# Altium schematic sheet FPGA_MISC.SchDoc(FPGA_MISC)
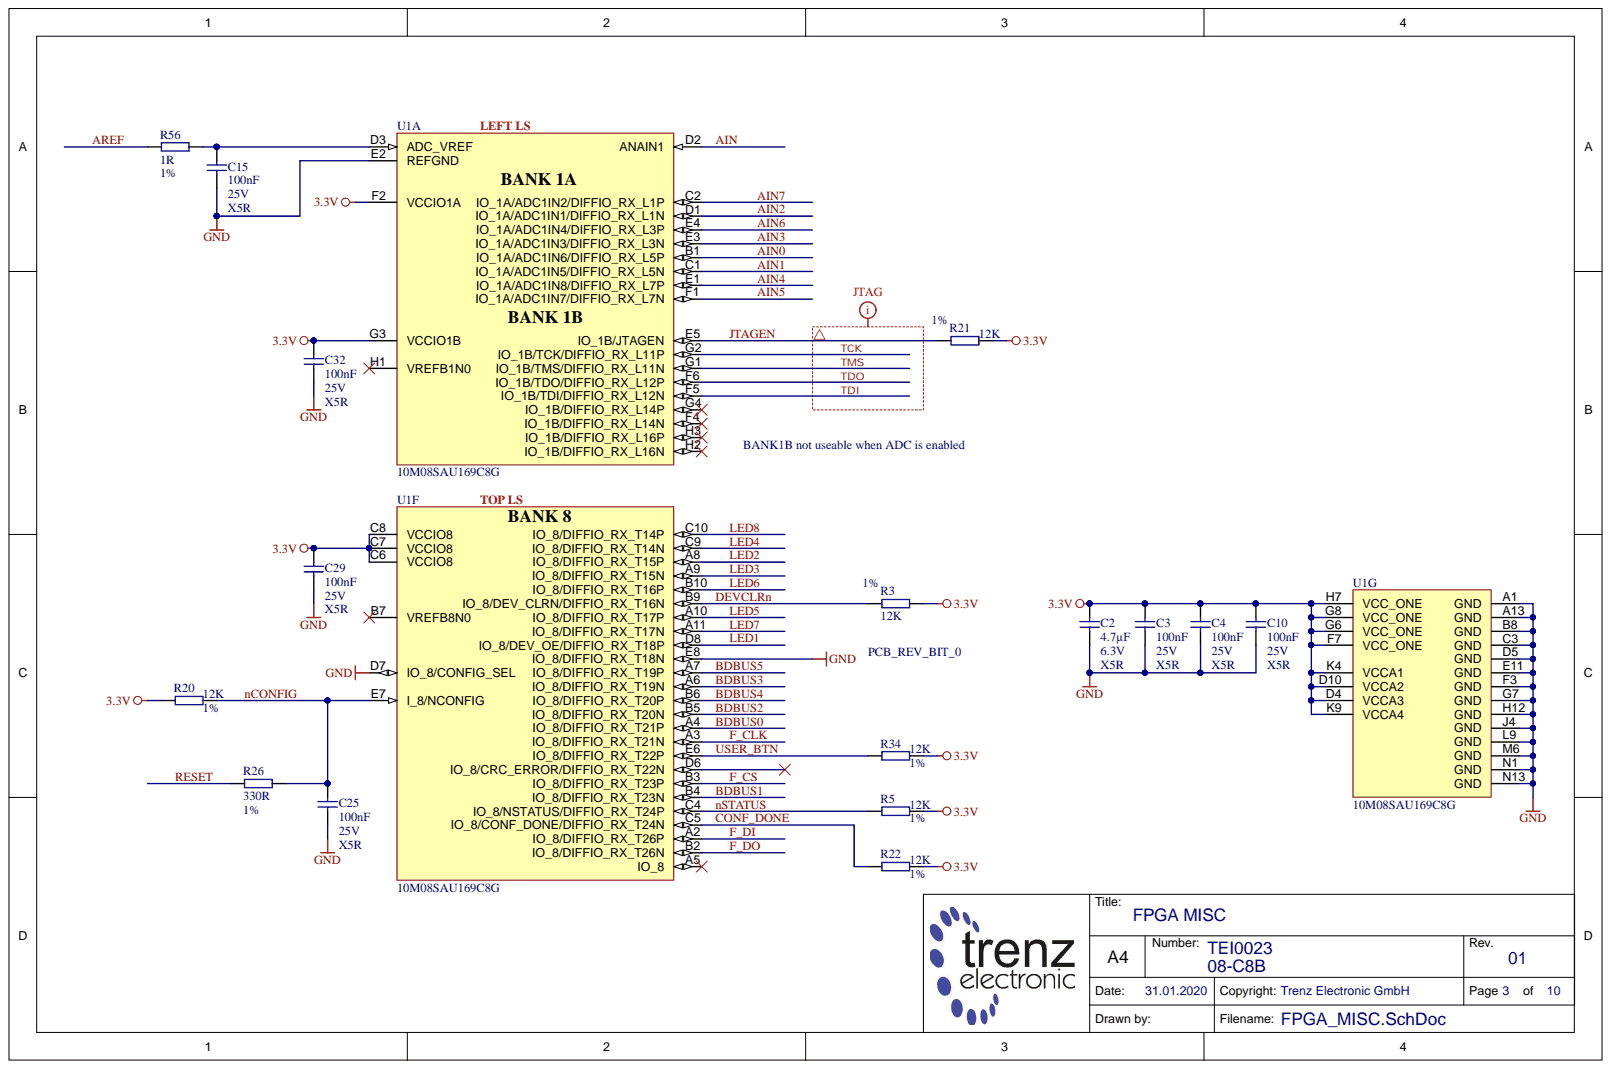
[diagram p0: FPGA_MISC.SchDoc]

## Parts
C2  4.7µF  Voltage: 6.3V  Technology: X5R  [CAPC1005X60N]
C3  100nF  Voltage: 25V  Technology: X5R  [CAPC1005X55N]
C4  100nF  Voltage: 25V  Technology: X5R  [CAPC1005X55N]
C10  100nF  Voltage: 25V  Technology: X5R  [CAPC1005X55N]
C15  100nF  Voltage: 25V  Technology: X5R  [CAPC1005X55N]
C25  100nF  Voltage: 25V  [CAPC1005X55N]
C29  100nF  Voltage: 25V  Technology: X5R  [CAPC1005X55N]
C32  100nF  Voltage: 25V  Technology: X5R  [CAPC1005X55N]
R3  12K  Tolerance: 1%  [RESC1005X40N]
R5  12K  Tolerance: 1%  [RESC1005X40N]
R20  12K  Tolerance: 1%  [RESC1005X40N]
R21  12K  Tolerance: 1%  [RESC1005X40N]
R22  12K  Tolerance: 1%  [RESC1005X40N]
R26  330R  Tolerance: 1%  [RESC1005X40N]
R34  12K  Tolerance: 1%  [RESC1005X40N]
R56  1R  Tolerance: 1%  [RESC1005X40N]
U1  10M08SAU169C8G  [BGA169C80P13X13_11X11X15]  (parts A,F,G)

## Nets
3.3V: C2.1 C3.1 C4.1 C10.1 C29.1 C32.1 R3.2 R5.2 R20.1 R21.2 R22.2 R34.2 U1.C6(VCCIO8) U1.C7(VCCIO8) U1.C8(VCCIO8) U1.D4(VCCA3) U1.D10(VCCA2) U1.F2(VCCIO1A) U1.F7(VCC_ONE) U1.G3(VCCIO1B) U1.G6(VCC_ONE) U1.G8(VCC_ONE) U1.H7(VCC_ONE) U1.K4(VCCA1) U1.K9(VCCA4)
GND: C2.2 C3.2 C4.2 C10.2 C15.2 C25.2 C29.2 C32.2 U1.A1(GND) U1.A13(GND) U1.B8(GND) U1.C3(GND) U1.D5(GND) U1.D7(IO_8/CONFIG_SEL) U1.E2(REFGND) U1.E8(IO_8/DIFFIO_RX_T18N) U1.E11(GND) U1.F3(GND) U1.G7(GND) U1.H12(GND) U1.J4(GND) U1.L9(GND) U1.M6(GND) U1.N1(GND) U1.N13(GND)
AIN: U1.D2(ANAIN1)
AIN0: U1.B1(IO_1A/ADC1IN6/DIFFIO_RX_L5P)
AIN1: U1.C1(IO_1A/ADC1IN5/DIFFIO_RX_L5N)
AIN2: U1.D1(IO_1A/ADC1IN1/DIFFIO_RX_L1N)
AIN3: U1.E3(IO_1A/ADC1IN3/DIFFIO_RX_L3N)
AIN4: U1.E1(IO_1A/ADC1IN8/DIFFIO_RX_L7P)
AIN5: U1.F1(IO_1A/ADC1IN7/DIFFIO_RX_L7N)
AIN6: U1.E4(IO_1A/ADC1IN4/DIFFIO_RX_L3P)
AIN7: U1.C2(IO_1A/ADC1IN2/DIFFIO_RX_L1P)
AREF: R56.1
BDBUS0: U1.A4(IO_8/DIFFIO_RX_T21P)
BDBUS1: U1.B4(IO_8/DIFFIO_RX_T23N)
BDBUS2: U1.B5(IO_8/DIFFIO_RX_T20N)
BDBUS3: U1.A6(IO_8/DIFFIO_RX_T19N)
BDBUS4: U1.B6(IO_8/DIFFIO_RX_T20P)
BDBUS5: U1.A7(IO_8/DIFFIO_RX_T19P)
CONF_DONE: R22.1 U1.C5(IO_8/CONF_DONE/DIFFIO_RX_T24N)
DEVCLRn: R3.1 U1.B9(IO_8/DEV_CLRN/DIFFIO_RX_T16N)
F_CLK: U1.A3(IO_8/DIFFIO_RX_T21N)
F_CS: U1.B3(IO_8/DIFFIO_RX_T23P)
F_DI: U1.A2(IO_8/DIFFIO_RX_T26P)
F_DO: U1.B2(IO_8/DIFFIO_RX_T26N)
JTAGEN: R21.1 U1.E5(IO_1B/JTAGEN)
LED1: U1.D8(IO_8/DEV_OE/DIFFIO_RX_T18P)
LED2: U1.A8(IO_8/DIFFIO_RX_T15P)
LED3: U1.A9(IO_8/DIFFIO_RX_T15N)
LED4: U1.C9(IO_8/DIFFIO_RX_T14N)
LED5: U1.A10(IO_8/DIFFIO_RX_T17P)
LED6: U1.B10(IO_8/DIFFIO_RX_T16P)
LED7: U1.A11(IO_8/DIFFIO_RX_T17N)
LED8: U1.C10(IO_8/DIFFIO_RX_T14P)
nCONFIG: C25.1 R20.2 R26.2 U1.E7(I_8/NCONFIG)
nSTATUS: R5.1 U1.C4(IO_8/NSTATUS/DIFFIO_RX_T24P)
RESET: R26.1
TCK: U1.G2(IO_1B/TCK/DIFFIO_RX_L11P)
TDI: U1.F5(IO_1B/TDI/DIFFIO_RX_L12N)
TDO: U1.F6(IO_1B/TDO/DIFFIO_RX_L12P)
TMS: U1.G1(IO_1B/TMS/DIFFIO_RX_L11N)
USER_BTN: R34.1 U1.E6(IO_8/DIFFIO_RX_T22P)
NetC15_1: C15.1 R56.2 U1.D3(ADC_VREF)
N00269:

Not connected: U1 A5(IO_8) B7(VREFB8N0) D6(IO_8/CRC_ERROR/DIFFIO_RX_T22N) F4(IO_1B/DIFFIO_RX_L14N) G4(IO_1B/DIFFIO_RX_L14P) H1(VREFB1N0) H2(IO_1B/DIFFIO_RX_L16N) H3(IO_1B/DIFFIO_RX_L16P)

## Library data of the parts
C2 = 24719: KON 4.7µF 6.3V X5R 20% SMD BF 1005 (0402); Value: 4.7u; Temperature-Range: -55/+85; Preferred: Yes; Mixed: SMT; Database Table Name: C_1005_0402; Tolerance: 20%; Library Name: TE.DbLib; Component Kind: Standard; Case: 1005 (0402); AN: 24719
C3 C4 C10 C15 C25 C29 C32 = 22472: KON 100nF 25V X5R ±10% SMD BF 1005 (0402); Value: 100n; Temperature-Range: -55/+85; Preferred: Yes; Mixed: SMT; Database Table Name: C_1005_0402; Library Name: TE.DbLib; Component Kind: Standard; Case: 1005 (0402); AN: 22472
  C25: Technology: X5R
R3 R5 R20-R22 R34 = 22598: WID SMD 12K 1% 0,063W  BF 1005 (0402); Preferred: Yes; Mixed: SMT; Database Table Name: R_1005_0402; Value: 12K; Technology: TK100; Library Name: TE.DbLib; Component Kind: Standard; Case: 1005 (0402); AN: 22598
R26 = 22762: WID SMD 330R 1% 0,063W  BF 1005 (0402); Value: 330; Preferred: Yes; Mixed: SMT; H-ART: RC0402FR-13330RL; Database Table Name: R_1005_0402; Technology: TK100; Library Name: TE.DbLib; Component Kind: Standard; Case: 1005 (0402); AN: 22762
R56 = 27510: WID SMD 1R 1% 0,063W  BF 1005 (0402); Value: 1; Mixed: SMT; Database Table Name: R_1005_0402; Technology: TK100; Library Name: TE.DbLib; Component Kind: Standard; Case: 1005 (0402); AN: 27510
U1 = 27930: Temperature-Range: 0/+85 (Tj); Mixed: SMT; Database Table Name: PROG_LOGIC; Storage-Temperature: -65/+150(Abs); Library Name: TE.DbLib; H-ART: 10M08SAU169C8G; Description: 10M08SAU169C8G; Component Kind: Standard; Case: LFBGA169; AN: 27930; HelpURL: b:\AD\adlib\datasheet\ALTERA_MAX10\
